# Altium schematic sheet Power.SchDoc
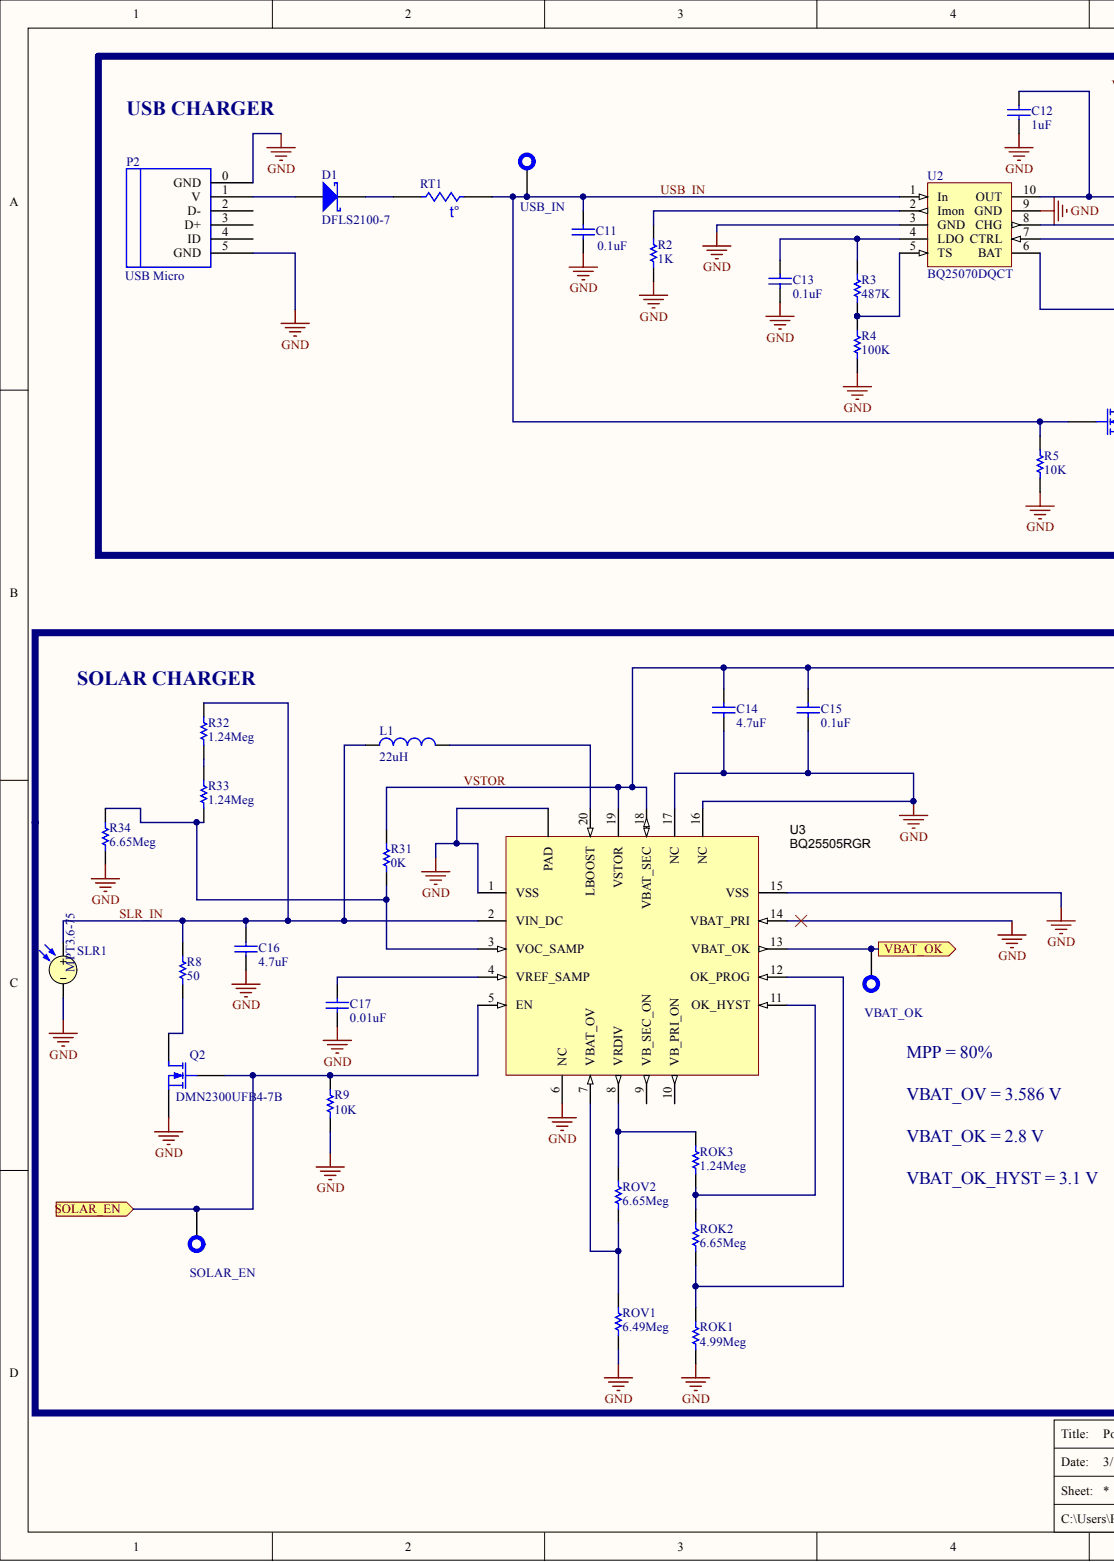
[diagram p0: Power.SchDoc, left]
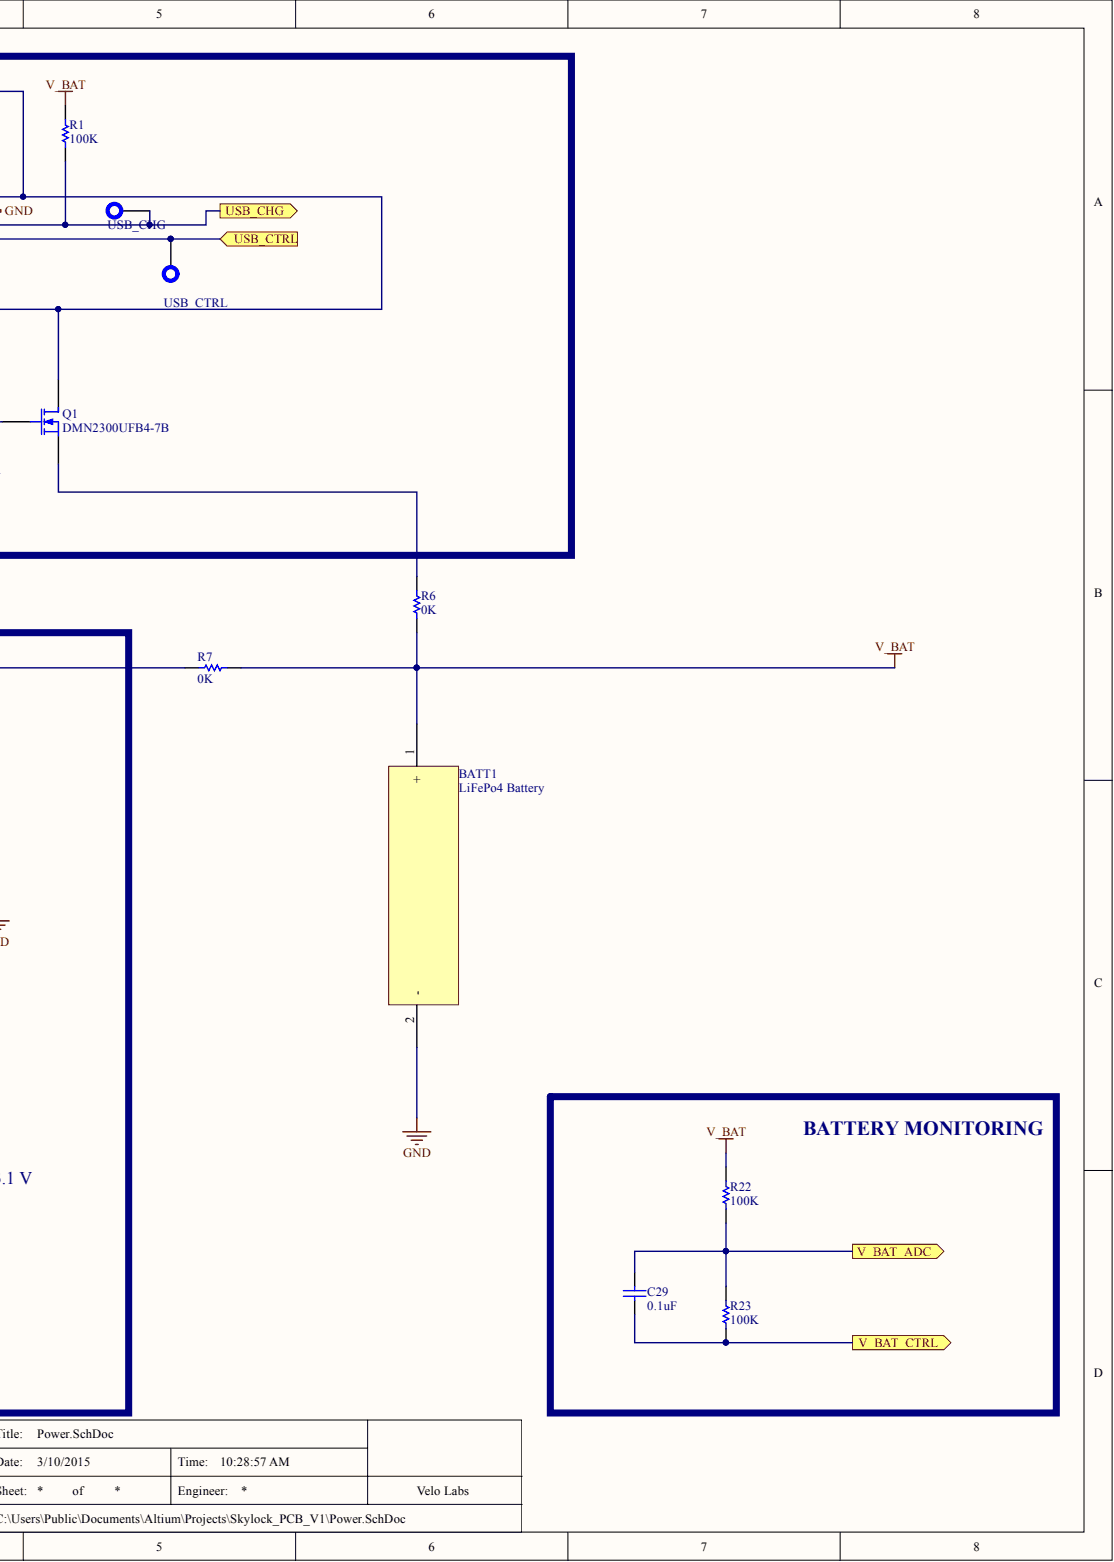
[diagram p1: Power.SchDoc, right]

## Parts
BATT1  LiFePo4 Battery  [Battery holder]
C11  0.1uF  [C0402]
C12  1uF  [C0402]
C13  0.1uF  [C0402]
C14  4.7uF  [C0603]
C15  0.1uF  [C0402]
C16  4.7uF  [C0603]
C17  0.01uF  [C0402]
C29  0.1uF  [C0402]
D1  DFLS2100-7  [POWERDI123]
L1  22uH  [LPS4018]
P2  USB Micro  [TE_micro_USB]
Q1  DMN2300UFB4-7B  [3-DFN]
Q2  DMN2300UFB4-7B  [3-DFN]
R1  100K  [R0402]
R2  1K  [R0402]
R3  487K  [R0402]
R4  100K  [R0402]
R5  10K  [R0402]
R6  0K  [R0603]
R7  0K  [R0603]
R8  50  [R1206]
R9  10K  [R0402]
R22  100K  [R0402]
R23  100K  [R0402]
R31  0K  [R0603]
R32  1.24Meg  [R0402]
R33  1.24Meg  [R0402]
R34  6.65Meg  [R0603]
ROK1  4.99Meg  [R0402]
ROK2  6.65Meg  [R0603]
ROK3  1.24Meg  [R0402]
ROV1  6.49Meg  [R0402]
ROV2  6.65Meg  [R0603]
RT1  PTC RESTTBLE 0.16A 48V CHIP 1206  [1206]  (value not drawn)
SLR1  MPT3.6-75  [Solar_pads]  (no BOM)
SOLAR_EN  Test Point  [TP]  (value not drawn)  (no BOM)
U2  BQ25070DQCT  [QFN50P200X300X80_HS-11N]
U3  BQ25505RGR  [RGR0020A]
USB_CHG  Test Point  [TP]  (value not drawn)  (no BOM)
USB_CTRL  Test Point  [TP]  (value not drawn)  (no BOM)
USB_IN  Test Point  [TP]  (value not drawn)  (no BOM)
VBAT_OK  Test Point  [TP]  (value not drawn)  (no BOM)

## Ports
SOLAR_EN, USB_CHG, USB_CTRL, V_BAT_ADC, V_BAT_CTRL, VBAT_OK

## Nets
GND: BATT1.2 C11.2 C12.2 C13.2 C14.2 C15.2 C16.2 C17.2 P2.0(GND) P2.5(GND) Q2.2 R2.2 R4.2 R5.2 R9.2 R34.1 ROK1.2 ROV1.2 SLR1.2 U2.3(GND) U2.9(GND) U2.11 U3.1(VSS) U3.6(NC) U3.14(VBAT_PRI) U3.15(VSS) U3.16(NC) U3.17(NC) U3.21(PAD)
V_BAT: BATT1.1 R1.1 R6.2 R7.2 R22.1
SLR_IN: C16.1 L1.1 R8.1 R32.2 SLR1.1 U3.2(VIN_DC)
SOLAR_EN: Q2.1 R9.1 SOLAR_EN.1 U3.5(EN) ; port SOLAR_EN
USB_CHG: R1.2 U2.8(CHG) USB_CHG.1 ; port USB_CHG
USB_CTRL: U2.7(CTRL) USB_CTRL.1 ; port USB_CTRL
USB_IN: C11.1 Q1.1 R5.1 RT1.1 U2.1(In) USB_IN.1
V_BAT_ADC: C29.1 R22.2 R23.1 ; port V_BAT_ADC
V_BAT_CTRL: C29.2 R23.2 ; port V_BAT_CTRL
VBAT_OK: U3.13(VBAT_OK) VBAT_OK.1 ; port VBAT_OK
VSTOR: C14.1 C15.1 R7.1 R31.2 U3.18(VBAT_SEC) U3.19(VSTOR)
NetC12_1: C12.1 Q1.3 U2.6(BAT) U2.10(OUT)
NetC13_1: C13.1 R3.1 U2.4(LDO)
NetC17_1: C17.1 U3.4(VREF_SAMP)
NetD1_1: D1.1 RT1.2
NetD1_2: D1.2 P2.1(V)
NetL1_2: L1.2 U3.20(LBOOST)
NetQ1_2: Q1.2 R6.1
NetQ2_3: Q2.3 R8.2
NetR2_1: R2.1 U2.2(Imon)
NetR3_2: R3.2 R4.1 U2.5(TS)
NetR31_1: R31.1 R33.1 R34.2 U3.3(VOC_SAMP)
NetR32_1: R32.1 R33.2
NetROK1_1: ROK1.1 ROK2.2 U3.12(OK_PROG)
NetROK2_1: ROK2.1 ROK3.2 U3.11(OK_HYST)
NetROK3_1: ROK3.1 ROV2.1 U3.8(VRDIV)
NetROV1_1: ROV1.1 ROV2.2 U3.7(VBAT_OV)

Not connected: P2 2(D-) 3(D+) 4(ID); U3 9(VB_SEC_ON) 10(VB_PRI_ON)

## Library data of the parts
BATT1 = LiFePo4 Battery: Battery; Component Kind: Standard; Library Name: VL_Skylock_Nordic.SCHLIB
C11 C13 C15 C29 = Cap Semi: Capacitor; Manufacturer: Murata Electronics North America; Manufacturer Part Number: GRM155R61A104KA01D; Capacitance: 0.1µF; Temperature Coefficient: X5R; Tolerance: ±10%; Voltage - Rated: 10V; Applications: General Purpose; Category: Capacitors; Component Kind: Standard; ComponentLink1Description: http://www.murata.com/~/media/webrenewal/support/library/catalog/products/capacitor/mlcc/c02e.ashx; ComponentLink1URL: http://www.murata.com/~/media/webrenewal/support/library/catalog/products/capacitor/mlcc/c02e.ashx; Features: -; Height - Seated (Max): -; LatestRevisionDate: 29-May-2009; LatestRevisionNote: IPC-7351 Footprint Added.; Lead Spacing: -; Lead Style: -; Mounting Type: Surface Mount, MLCC; Operating Temperature: -55°C ~ 85°C; Package / Case: 0402 (1005 Metric); PackageDescription: Chip Capacitor; PackageReference: C1206; Packaging: Cut Tape (CT); Pricing 1: 1=0.1, 10=0.012, 50=0.0066, 100=0.0055, 250=0.00452, 500=0.00386, 1000=0.003, 5000=0.0025 (USD); Published: 8-Jun-2000; Ratings: -; RoHS: RoHS Compliant; Signal Integrity: Cap Semi; Simulation: CAP; Size / Dimension: 0.039" L x 0.020" W (1.00mm x 0.50mm); Supplier 1: Digi-Key; Supplier Part Number 1: 490-1318-1-ND; Thickness (Max): 0.022" (0.55mm); Value: 0.1uF; Library Name: VL_Skylock_Nordic.SCHLIB; Publisher: Altium Limited
  C11 C15 C29: Stock 1: 7992964
  C13: Stock 1: 7995514
C12 = Cap Semi: Capacitor; Manufacturer: Murata Electronics North America; Manufacturer Part Number: GRM155R60J105KE19D; Capacitance: 1µF; Temperature Coefficient: X5R; Tolerance: ±10%; Voltage - Rated: 6.3V; Applications: General Purpose; Category: Capacitors; Component Kind: Standard; ComponentLink1Description: http://www.murata.com/~/media/webrenewal/support/library/catalog/products/capacitor/mlcc/c02e.ashx; ComponentLink1URL: http://www.murata.com/~/media/webrenewal/support/library/catalog/products/capacitor/mlcc/c02e.ashx; Features: -; Height - Seated (Max): -; LatestRevisionDate: 29-May-2009; LatestRevisionNote: IPC-7351 Footprint Added.; Lead Spacing: -; Lead Style: -; Mounting Type: Surface Mount, MLCC; Operating Temperature: -55°C ~ 85°C; Package / Case: 0402 (1005 Metric); PackageDescription: Chip Capacitor; PackageReference: C1206; Packaging: Cut Tape (CT); Pricing 1: 1=0.1, 10=0.035, 50=0.0192, 100=0.0163, 250=0.01332, 500=0.01138, 1000=0.0087, 5000=0.00725 (USD); Published: 8-Jun-2000; Ratings: -; RoHS: RoHS Compliant; Signal Integrity: Cap Semi; Simulation: CAP; Size / Dimension: 0.039" L x 0.020" W (1.00mm x 0.50mm); Stock 1: 3470799; Supplier 1: Digi-Key; Supplier Part Number 1: 490-1320-1-ND; Thickness (Max): 0.022" (0.55mm); Value: 1uF; Library Name: VL_Skylock_Nordic.SCHLIB; Publisher: Altium Limited
C14 C16 = Cap Semi: Capacitor; Manufacturer: Taiyo Yuden; Manufacturer Part Number: LMK107BJ475KA-T; Capacitance: 4.7µF; Temperature Coefficient: X5R; Tolerance: ±10%; Voltage - Rated: 10V; Applications: General Purpose; Category: Capacitors; Component Kind: Standard; ComponentLink1Description: http://www.yuden.co.jp/or/product/category/capacitor/LMK107BJ475KA-T.pdf; ComponentLink1URL: http://www.yuden.co.jp/or/product/category/capacitor/LMK107BJ475KA-T.pdf; Features: -; Height - Seated (Max): -; LatestRevisionDate: 29-May-2009; LatestRevisionNote: IPC-7351 Footprint Added.; Lead Spacing: -; Lead Style: -; Mounting Type: Surface Mount, MLCC; Operating Temperature: -55°C ~ 85°C; Package / Case: 0603 (1608 Metric); PackageDescription: Chip Capacitor; PackageReference: C1206; Packaging: Cut Tape (CT); Pricing 1: 1=0.23, 10=0.157, 50=0.095, 100=0.0798, 250=0.0646, 500=0.057, 1000=0.04845 (USD); Published: 8-Jun-2000; Ratings: -; RoHS: RoHS Compliant; Signal Integrity: Cap Semi; Simulation: CAP; Size / Dimension: 0.063" L x 0.031" W (1.60mm x 0.80mm); Stock 1: 439312; Supplier 1: Digi-Key; Supplier Part Number 1: 587-1441-1-ND; Thickness (Max): 0.035" (0.90mm); Value: 4.7uF; Library Name: VL_Skylock_Nordic.SCHLIB; Publisher: Altium Limited
C17 = Cap Semi: Capacitor; Manufacturer: Murata Electronics North America; Manufacturer Part Number: GRM155R71E103KA01D; Capacitance: 10000pF; Temperature Coefficient: X7R; Tolerance: ±10%; Voltage - Rated: 25V; Applications: General Purpose; Category: Capacitors; Component Kind: Standard; ComponentLink1Description: http://www.murata.com/~/media/webrenewal/support/library/catalog/products/capacitor/mlcc/c02e.ashx; ComponentLink1URL: http://www.murata.com/~/media/webrenewal/support/library/catalog/products/capacitor/mlcc/c02e.ashx; Features: -; Height - Seated (Max): -; LatestRevisionDate: 29-May-2009; LatestRevisionNote: IPC-7351 Footprint Added.; Lead Spacing: -; Lead Style: -; Mounting Type: Surface Mount, MLCC; Operating Temperature: -55°C ~ 125°C; Package / Case: 0402 (1005 Metric); PackageDescription: Chip Capacitor; PackageReference: C1206; Packaging: Cut Tape (CT); Pricing 1: 1=0.1, 10=0.012, 50=0.0066, 100=0.0057, 250=0.00464, 500=0.00396, 1000=0.00309, 5000=0.00258 (USD); Published: 8-Jun-2000; Ratings: -; RoHS: RoHS Compliant; Signal Integrity: Cap Semi; Simulation: CAP; Size / Dimension: 0.039" L x 0.020" W (1.00mm x 0.50mm); Stock 1: 3508455; Supplier 1: Digi-Key; Supplier Part Number 1: 490-1312-1-ND; Thickness (Max): 0.022" (0.55mm); Value: 0.01uF; Library Name: VL_Skylock_Nordic.SCHLIB; Publisher: Altium Limited
D1 = DFLS2100-7: DIODE SCHOTKY 100V 2A POWERDI123; Manufacturer: Diodes Incorporated; Capacitance @ Vr, F: 36pF @ 5V, 1MHz; Current - Reverse Leakage @ Vr: 1µA @ 100V; Diode Type: Schottky; Speed: Fast Recovery =< 500ns, > 200mA (Io); Voltage - Forward (Vf) (Max) @ If: 860mV @ 2A; Category: Discrete Semiconductor Products; Component Kind: Standard; ComponentLink1Description: http://www.diodes.com/datasheets/ds31475.pdf; ComponentLink1URL: http://www.diodes.com/datasheets/ds31475.pdf; Current - Average Rectified (Io): 2A; Description 1: DIODE SCHOTKY 100V 2A POWERDI123; Manufacturer Part Number: DFLS2100-7; Mounting Type: Surface Mount; Operating Temperature - Junction: -55°C ~ 175°C; Package / Case: 2-SMD, Flat Lead; Packaging: Cut Tape (CT); Pricing 1: 1=0.74, 10=0.65, 25=0.5744, 100=0.5004, 250=0.4356, 500=0.3708, 1000=0.297 (USD); Reverse Recovery Time (trr): -; RoHS: RoHS Compliant; Stock 1: 21950; Supplier 1: Digi-Key; Supplier Device Package: PowerDI™ 123; Supplier Part Number 1: DFLS2100-7DICT-ND; Voltage - DC Reverse (Vr) (Max): 100V; Library Name: VL_Skylock_Nordic.SCHLIB
L1 = Inductor: Fixed Inductors 22 uH 0.9 A 20% SMD Shielded; Manufacturer Part Number: LPS4018-223MRC; Brand: Coilcraft; Case Height: 1.7 mm; Case Length: 4 mm; Code_IEC: 1005; Core Material: Ferrite; Maximum DC Current: 830 mA; Maximum DC Resistance: 360 mOhms; Product Status: New Product; Self Resonant Frequency: 26 MHz; Test Frequency: 100 kHz; Type: Shielded Power Inductor; Case Width: 4 mm; Category: Fixed Inductors; Code_JEITA: 0402; Component Kind: Standard; ComponentLink1Description: http://www.coilcraft.com/lps4018.cfm; ComponentLink1URL: http://www.coilcraft.com/lps4018.cfm; Description 1: Fixed Inductors 22 uH 0.9 A 20% SMD Shielded; Inductance: 22 uH; LatestRevisionDate: 29-May-2009; LatestRevisionNote: IPC-7351 Footprint Added.; Manufacturer: Coilcraft; Minimum Order Quantity: 1000; Operating Temperature Range: - 40 C to + 85 C; PackageDescription: Chip Inductor; PackageReference: 0402-A; Packaging: Reel; Pricing 1: 1000=0.684, 2000=0.637 (AUD); Product: Power Inductors; Published: 8-Jun-2000; Reeling: True; RoHS: RoHS Compliant; RoHS - Mouser: Y; Series: LPS4018; Shielding: Shielded; Signal Integrity: Inductor; Simulation: INDUCTOR; Stock 1: 7000; Supplier 1: Mouser; Supplier Part Number 1: 994-LPS4018-223MRC; Termination Style: SMD/SMT; Tolerance: 20 %; Value: 22uH; Library Name: VL_Skylock_Nordic.SCHLIB; Publisher: Altium Limited
P2 = Micro_USB_splashproof: TE Connectivity SPLASH PROOF MICRO 5P USB AB-TYPE; Manufacturer Part Number: 1551629-2; Brand: TE Connectivity; Category: TE Connectivity; Component Kind: Standard; ComponentLink1Description: http://www.te.com/catalog/pn/en/1551629-2; ComponentLink1URL: http://www.te.com/catalog/pn/en/1551629-2; Manufacturer: TE Connectivity; Minimum Order Quantity: 56000; Packaging: Bulk; Pricing 1: 56000=12.74 (AUD); Reeling: False; RoHS: RoHS Compliant; RoHS - Mouser: Y; Standard Pack Qty: 1000; Stock 1: 0; Supplier 1: Mouser; Supplier Part Number 1: 571-1551629-2; Library Name: VL_Skylock_Nordic.SCHLIB
Q1 Q2 = DMN2300UFB4-7B: MOSF N CH 20V 1.3A DFN1006H4-3; Manufacturer: Diodes Inc; Current - Continuous Drain (Id) @ 25° C: 1.3A (Ta); FET Feature: Logic Level Gate; FET Type: MOSFET N-Channel, Metal Oxide; Gate Charge (Qg) @ Vgs: 1.6nC @ 4.5V; Input Capacitance (Ciss) @ Vds: 64.3pF @ 25V; Power - Max: 470mW; Rds On (Max) @ Id, Vgs: 175 mOhm @ 300mA, 4.5V; Vgs(th) (Max) @ Id: 950mV @ 250µA; Category: Discrete Semiconductor Products; Component Kind: Standard; ComponentLink1Description: http://www.diodes.com/datasheets/DMN2300UFB4.pdf; ComponentLink1URL: http://www.diodes.com/datasheets/DMN2300UFB4.pdf; Description 1: MOSF N CH 20V 1.3A DFN1006H4-3; Drain to Source Voltage (Vdss): 20V; Manufacturer Part Number: DMN2300UFB4-7B; Mounting Type: Surface Mount; Package / Case: 3-XFDFN; Packaging: Digi-Reel®; Pricing 1: 1=0.48, 10=0.348, 25=0.2704, 100=0.2048, 250=0.14476, 500=0.11588, 1000=0.08888, 2500=0.07725, 5000=0.06938 (USD); RoHS: RoHS Compliant; Simulation: NMOS; Stock 1: 61054; Supplier 1: Digi-Key; Supplier Device Package: 3-DFN1006H4 (1.0x0.6); Supplier Part Number 1: DMN2300UFB4-7BDIDKR-ND; Library Name: VL_Skylock_Nordic.SCHLIB
R1 R22 R23 = Res: Resistor; Manufacturer: Panasonic Electronic Components; Manufacturer Part Number: ERJ-2GEJ104X; Power (Watts): 0.1W, 1/10W; Temperature Coefficient: ±200ppm/°C; Tolerance: ±5%; Category: Resistors; Component Kind: Standard; ComponentLink1Description: http://industrial.panasonic.com/www-cgi/jvcr13pz.cgi?E+PZ+3+AOA0001+ERJ2GEJ104X+7+WW; ComponentLink1URL: http://industrial.panasonic.com/www-cgi/jvcr13pz.cgi?E+PZ+3+AOA0001+ERJ2GEJ104X+7+WW; Composition: Thick Film; Features: Automotive AEC-Q200; Height: 0.016" (0.40mm); LatestRevisionDate: 17-Jul-2002; LatestRevisionNote: Re-released for DXP Platform.; Number of Terminations: 2; Operating Temperature: -55°C ~ 155°C; Package / Case: 0402 (1005 Metric); PackageDescription: Axial Device, Thru-Hole; 2 Leads; 0.3 in Pin Spacing; PackageReference: AXIAL-0.3; Packaging: Cut Tape (CT); Pricing 1: 1=0.1, 50=0.0138, 100=0.0105, 250=0.0078, 500=0.00576, 1000=0.00384, 5000=0.0027 (USD); Published: 8-Jun-2000; Resistance (Ohms): 100k; RoHS: RoHS Compliant; Signal Integrity: Res; Simulation: RESISTOR; Size / Dimension: 0.039" L x 0.020" W (1.00mm x 0.50mm); Stock 1: 1549135; Supplier 1: Digi-Key; Supplier Device Package: 0402; Supplier Part Number 1: P100KJCT-ND; Value: 100K; Library Name: VL_Skylock_Nordic.SCHLIB; Publisher: Altium Limited
R2 = Res: Resistor; Manufacturer: Panasonic Electronic Components; Manufacturer Part Number: ERJ-2RKF1001X; Power (Watts): 0.1W, 1/10W; Temperature Coefficient: ±100ppm/°C; Tolerance: ±1%; Category: Resistors; Component Kind: Standard; ComponentLink1Description: http://industrial.panasonic.com/www-cgi/jvcr13pz.cgi?E+PZ+3+AOA0002+ERJ2RKF1001X+7+WW; ComponentLink1URL: http://industrial.panasonic.com/www-cgi/jvcr13pz.cgi?E+PZ+3+AOA0002+ERJ2RKF1001X+7+WW; Composition: Thick Film; Features: Automotive AEC-Q200; Height: 0.016" (0.40mm); LatestRevisionDate: 17-Jul-2002; LatestRevisionNote: Re-released for DXP Platform.; Number of Terminations: 2; Operating Temperature: -55°C ~ 155°C; Package / Case: 0402 (1005 Metric); PackageDescription: Axial Device, Thru-Hole; 2 Leads; 0.3 in Pin Spacing; PackageReference: AXIAL-0.3; Packaging: Cut Tape (CT); Pricing 1: 1=0.1, 50=0.0196, 100=0.0149, 250=0.01104, 500=0.00816, 1000=0.00544, 5000=0.00383 (USD); Published: 8-Jun-2000; Resistance (Ohms): 1k; RoHS: RoHS Compliant; Signal Integrity: Res; Simulation: RESISTOR; Size / Dimension: 0.039" L x 0.020" W (1.00mm x 0.50mm); Stock 1: 2868758; Supplier 1: Digi-Key; Supplier Device Package: 0402; Supplier Part Number 1: P1.00KLCT-ND; Value: 1K; Library Name: VL_Skylock_Nordic.SCHLIB; Publisher: Altium Limited
R3 = Res: Resistor; Manufacturer: Panasonic Electronic Components; Manufacturer Part Number: ERJ-2RKF4873X; Power (Watts): 0.1W, 1/10W; Temperature Coefficient: ±100ppm/°C; Tolerance: ±1%; Category: Resistors; Component Kind: Standard; ComponentLink1Description: http://industrial.panasonic.com/www-cgi/jvcr13pz.cgi?E+PZ+3+AOA0002+ERJ2RKF4873X+7+WW; ComponentLink1URL: http://industrial.panasonic.com/www-cgi/jvcr13pz.cgi?E+PZ+3+AOA0002+ERJ2RKF4873X+7+WW; Composition: Thick Film; Features: Automotive AEC-Q200; Height: 0.016" (0.40mm); LatestRevisionDate: 17-Jul-2002; LatestRevisionNote: Re-released for DXP Platform.; Number of Terminations: 2; Operating Temperature: -55°C ~ 155°C; Package / Case: 0402 (1005 Metric); PackageDescription: Axial Device, Thru-Hole; 2 Leads; 0.3 in Pin Spacing; PackageReference: AXIAL-0.3; Packaging: Cut Tape (CT); Pricing 1: 1=0.1, 50=0.0196, 100=0.0149, 250=0.01104, 500=0.00816, 1000=0.00544, 5000=0.00383 (USD); Published: 8-Jun-2000; Resistance (Ohms): 487k; RoHS: RoHS Compliant; Signal Integrity: Res; Simulation: RESISTOR; Size / Dimension: 0.039" L x 0.020" W (1.00mm x 0.50mm); Stock 1: 78640; Supplier 1: Digi-Key; Supplier Device Package: 0402; Supplier Part Number 1: P487KLCT-ND; Value: 487K; Library Name: VL_Skylock_Nordic.SCHLIB; Publisher: Altium Limited
R4 = Res: Resistor; Manufacturer: Panasonic Electronic Components; Manufacturer Part Number: ERJ-2RKF1003X; Power (Watts): 0.1W, 1/10W; Temperature Coefficient: ±100ppm/°C; Tolerance: ±1%; Category: Resistors; Component Kind: Standard; ComponentLink1Description: http://industrial.panasonic.com/www-cgi/jvcr13pz.cgi?E+PZ+3+AOA0002+ERJ2RKF1003X+7+WW; ComponentLink1URL: http://industrial.panasonic.com/www-cgi/jvcr13pz.cgi?E+PZ+3+AOA0002+ERJ2RKF1003X+7+WW; Composition: Thick Film; Features: Automotive AEC-Q200; Height: 0.016" (0.40mm); LatestRevisionDate: 17-Jul-2002; LatestRevisionNote: Re-released for DXP Platform.; Number of Terminations: 2; Operating Temperature: -55°C ~ 155°C; Package / Case: 0402 (1005 Metric); PackageDescription: Axial Device, Thru-Hole; 2 Leads; 0.3 in Pin Spacing; PackageReference: AXIAL-0.3; Packaging: Cut Tape (CT); Pricing 1: 1=0.1, 50=0.0196, 100=0.0149, 250=0.01104, 500=0.00816, 1000=0.00544, 5000=0.00383 (USD); Published: 8-Jun-2000; Resistance (Ohms): 100k; RoHS: RoHS Compliant; Signal Integrity: Res; Simulation: RESISTOR; Size / Dimension: 0.039" L x 0.020" W (1.00mm x 0.50mm); Stock 1: 4313571; Supplier 1: Digi-Key; Supplier Device Package: 0402; Supplier Part Number 1: P100KLCT-ND; Value: 100K; Library Name: VL_Skylock_Nordic.SCHLIB; Publisher: Altium Limited
R5 R9 = Res: Resistor; Manufacturer: Panasonic Electronic Components; Manufacturer Part Number: ERJ-2GEJ102X; Power (Watts): 0.1W, 1/10W; Resistance (Ohms): 1k; Temperature Coefficient: ±200ppm/°C; Tolerance: ±5%; Category: Resistors; Component Kind: Standard; ComponentLink1Description: http://industrial.panasonic.com/www-cgi/jvcr13pz.cgi?E+PZ+3+AOA0001+ERJ2GEJ102X+7+WW; ComponentLink1URL: http://industrial.panasonic.com/www-cgi/jvcr13pz.cgi?E+PZ+3+AOA0001+ERJ2GEJ102X+7+WW; Composition: Thick Film; Features: Automotive AEC-Q200; Height: 0.016" (0.40mm); LatestRevisionDate: 17-Jul-2002; LatestRevisionNote: Re-released for DXP Platform.; Number of Terminations: 2; Operating Temperature: -55°C ~ 155°C; Package / Case: 0402 (1005 Metric); PackageDescription: Axial Device, Thru-Hole; 2 Leads; 0.3 in Pin Spacing; PackageReference: AXIAL-0.3; Packaging: Cut Tape (CT); Pricing 1: 1=0.1, 50=0.0138, 100=0.0105, 250=0.0078, 500=0.00576, 1000=0.00384, 5000=0.0027 (USD); Published: 8-Jun-2000; RoHS: RoHS Compliant; Signal Integrity: Res; Simulation: RESISTOR; Size / Dimension: 0.039" L x 0.020" W (1.00mm x 0.50mm); Supplier 1: Digi-Key; Supplier Device Package: 0402; Supplier Part Number 1: P1.0KJCT-ND; Value: 10K; Library Name: VL_Skylock_Nordic.SCHLIB; Publisher: Altium Limited
  R5: Stock 1: 1267887
  R9: Stock 1: 1267587
R6 R7 R31 = Res: Resistor; Manufacturer: Panasonic Electronic Components; Manufacturer Part Number: ERJ-3GEY0R00V; Power (Watts): 0.1W, 1/10W; Resistance (Ohms): 0.0; Tolerance: Jumper; Category: Resistors; Component Kind: Standard; ComponentLink1Description: http://industrial.panasonic.com/www-cgi/jvcr13pz.cgi?E+PZ+3+AOA0001+ERJ3GEY0R00V+7+WW; ComponentLink1URL: http://industrial.panasonic.com/www-cgi/jvcr13pz.cgi?E+PZ+3+AOA0001+ERJ3GEY0R00V+7+WW; Composition: Thick Film; Features: Automotive AEC-Q200; Height: 0.022" (0.55mm); LatestRevisionDate: 17-Jul-2002; LatestRevisionNote: Re-released for DXP Platform.; Number of Terminations: 2; Operating Temperature: -55°C ~ 155°C; Package / Case: 0603 (1608 Metric); PackageDescription: Axial Device, Thru-Hole; 2 Leads; 0.3 in Pin Spacing; PackageReference: AXIAL-0.3; Packaging: Cut Tape (CT); Pricing 1: 1=0.1, 50=0.0126, 100=0.0096, 250=0.00716, 500=0.00528, 1000=0.00352, 2500=0.00297 (USD); Published: 8-Jun-2000; RoHS: RoHS Compliant; Signal Integrity: Res; Simulation: RESISTOR; Size / Dimension: 0.063" L x 0.031" W (1.60mm x 0.80mm); Supplier 1: Digi-Key; Supplier Device Package: 0603; Supplier Part Number 1: P0.0GCT-ND; Temperature Coefficient: -; Value: 0K; Library Name: VL_Skylock_Nordic.SCHLIB; Publisher: Altium Limited
  R6 R7: Stock 1: 5836923
  R31: Stock 1: 5282845
R8 = Res: Resistor; Manufacturer: Panasonic Electronic Components; Manufacturer Part Number: ERJ-8ENF51R1V; Power (Watts): 0.25W, 1/4W; Resistance (Ohms): 51.1; Temperature Coefficient: ±100ppm/°C; Tolerance: ±1%; Category: Resistors; Component Kind: Standard; ComponentLink1Description: http://industrial.panasonic.com/www-cgi/jvcr13pz.cgi?E+PZ+3+AOA0002+ERJ8ENF51R1V+7+WW; ComponentLink1URL: http://industrial.panasonic.com/www-cgi/jvcr13pz.cgi?E+PZ+3+AOA0002+ERJ8ENF51R1V+7+WW; Composition: Thick Film; Features: Automotive AEC-Q200; Height: 0.028" (0.70mm); LatestRevisionDate: 17-Jul-2002; LatestRevisionNote: Re-released for DXP Platform.; Number of Terminations: 2; Operating Temperature: -55°C ~ 155°C; Package / Case: 1206 (3216 Metric); PackageDescription: Axial Device, Thru-Hole; 2 Leads; 0.3 in Pin Spacing; PackageReference: AXIAL-0.3; Packaging: Cut Tape (CT); Pricing 1: 1=0.1, 50=0.0368, 100=0.028, 250=0.0208, 500=0.01536, 1000=0.01024 (USD); Published: 8-Jun-2000; RoHS: RoHS Compliant; Signal Integrity: Res; Simulation: RESISTOR; Size / Dimension: 0.126" L x 0.063" W (3.20mm x 1.60mm); Stock 1: 66578; Supplier 1: Digi-Key; Supplier Device Package: 1206; Supplier Part Number 1: P51.1FCT-ND; Value: 50; Library Name: VL_Skylock_Nordic.SCHLIB; Publisher: Altium Limited
R32 R33 ROK3 = Res: Resistor; Manufacturer: Vishay Dale; Manufacturer Part Number: CRCW04021M24FKED; Power (Watts): 0.063W, 1/16W; Resistance (Ohms): 1.24M; Temperature Coefficient: ±100ppm/°C; Tolerance: ±1%; Category: Resistors; Component Kind: Standard; ComponentLink1Description: http://www.vishay.com/docs/20035/dcrcwe3.pdf; ComponentLink1URL: http://www.vishay.com/docs/20035/dcrcwe3.pdf; Composition: Thick Film; Features: Automotive AEC-Q200; Height: 0.016" (0.40mm); LatestRevisionDate: 17-Jul-2002; LatestRevisionNote: Re-released for DXP Platform.; Number of Terminations: 2; Operating Temperature: -55°C ~ 155°C; Package / Case: 0402 (1005 Metric); PackageDescription: Axial Device, Thru-Hole; 2 Leads; 0.3 in Pin Spacing; PackageReference: AXIAL-0.3; Packaging: Cut Tape (CT); Pricing 1: 10=0.083, 50=0.0444, 200=0.0255, 1000=0.0174 (USD); Published: 8-Jun-2000; RoHS: RoHS Compliant; Signal Integrity: Res; Simulation: RESISTOR; Size / Dimension: 0.039" L x 0.020" W (1.00mm x 0.50mm); Supplier 1: Digi-Key; Supplier Device Package: 0402 (1005 Metric); Supplier Part Number 1: 541-1.24MLCT-ND; Value: 1.24Meg; Library Name: VL_Skylock_Nordic.SCHLIB; Publisher: Altium Limited
  R32 R33: Stock 1: 25169
  ROK3: Stock 1: 25129
R34 ROK2 ROV2 = Res: Resistor; Manufacturer: Vishay Dale; Manufacturer Part Number: CRCW06036M65FKEA; Power (Watts): 0.1W, 1/10W; Resistance (Ohms): 6.65M; Temperature Coefficient: ±100ppm/°C; Tolerance: ±1%; Category: Resistors; Component Kind: Standard; ComponentLink1Description: http://www.vishay.com/docs/20035/dcrcwe3.pdf; ComponentLink1URL: http://www.vishay.com/docs/20035/dcrcwe3.pdf; Composition: Thick Film; Features: Automotive AEC-Q200; Height: 0.020" (0.50mm); LatestRevisionDate: 17-Jul-2002; LatestRevisionNote: Re-released for DXP Platform.; Number of Terminations: 2; Operating Temperature: -55°C ~ 155°C; Package / Case: 0603 (1608 Metric); PackageDescription: Axial Device, Thru-Hole; 2 Leads; 0.3 in Pin Spacing; PackageReference: AXIAL-0.3; Packaging: Cut Tape (CT); Pricing 1: 10=0.081, 50=0.0436, 200=0.0251, 1000=0.01711 (USD); Published: 8-Jun-2000; RoHS: RoHS Compliant; Signal Integrity: Res; Simulation: RESISTOR; Size / Dimension: 0.061" L x 0.033" W (1.55mm x 0.85mm); Stock 1: 19192; Supplier 1: Digi-Key; Supplier Device Package: 0603 (1608 Metric); Supplier Part Number 1: 541-6.65MHCT-ND; Value: 6.65Meg; Library Name: VL_Skylock_Nordic.SCHLIB; Publisher: Altium Limited
ROK1 = Res: Resistor; Manufacturer: Vishay Dale; Manufacturer Part Number: CRCW04024M99FKED; Power (Watts): 0.063W, 1/16W; Resistance (Ohms): 4.99M; Temperature Coefficient: ±100ppm/°C; Tolerance: ±1%; Category: Resistors; Component Kind: Standard; ComponentLink1Description: http://www.vishay.com/docs/20035/dcrcwe3.pdf; ComponentLink1URL: http://www.vishay.com/docs/20035/dcrcwe3.pdf; Composition: Thick Film; Features: Automotive AEC-Q200; Height: 0.016" (0.40mm); LatestRevisionDate: 17-Jul-2002; LatestRevisionNote: Re-released for DXP Platform.; Number of Terminations: 2; Operating Temperature: -55°C ~ 155°C; Package / Case: 0402 (1005 Metric); PackageDescription: Axial Device, Thru-Hole; 2 Leads; 0.3 in Pin Spacing; PackageReference: AXIAL-0.3; Packaging: Cut Tape (CT); Pricing 1: 10=0.083, 50=0.0444, 200=0.0255, 1000=0.0174 (USD); Published: 8-Jun-2000; RoHS: RoHS Compliant; Signal Integrity: Res; Simulation: RESISTOR; Size / Dimension: 0.039" L x 0.020" W (1.00mm x 0.50mm); Stock 1: 393089; Supplier 1: Digi-Key; Supplier Device Package: 0402 (1005 Metric); Supplier Part Number 1: 541-4.99MLCT-ND; Value: 4.99Meg; Library Name: VL_Skylock_Nordic.SCHLIB; Publisher: Altium Limited
ROV1 = Res: Resistor; Manufacturer: Stackpole Electronics Inc; Manufacturer Part Number: RMCF0402FT6M49; Power (Watts): 0.063W, 1/16W; Resistance (Ohms): 6.49M; Temperature Coefficient: ±100ppm/°C; Tolerance: ±1%; Category: Resistors; Component Kind: Standard; ComponentLink1Description: http://www.seielect.com/Catalog/SEI-RMCF_RMCP.pdf; ComponentLink1URL: http://www.seielect.com/Catalog/SEI-RMCF_RMCP.pdf; Composition: Thick Film; Features: Automotive AEC-Q200; Height: 0.016" (0.40mm); LatestRevisionDate: 17-Jul-2002; LatestRevisionNote: Re-released for DXP Platform.; Number of Terminations: 2; Operating Temperature: -55°C ~ 155°C; Package / Case: 0402 (1005 Metric); PackageDescription: Axial Device, Thru-Hole; 2 Leads; 0.3 in Pin Spacing; PackageReference: AXIAL-0.3; Packaging: Cut Tape (CT); Pricing 1: 1=0.1, 10=0.025, 50=0.0138, 100=0.0105, 250=0.0078, 500=0.00576, 1000=0.00384, 2500=0.00324, 5000=0.0027 (USD); Published: 8-Jun-2000; RoHS: RoHS Compliant; Signal Integrity: Res; Simulation: RESISTOR; Size / Dimension: 0.039" L x 0.020" W (1.00mm x 0.50mm); Stock 1: 5415; Supplier 1: Digi-Key; Supplier Device Package: 0402; Supplier Part Number 1: RMCF0402FT6M49CT-ND; Value: 6.49Meg; Library Name: VL_Skylock_Nordic.SCHLIB; Publisher: Altium Limited
RT1 = Res Thermal: Thermistor; Manufacturer: Bel Fuse Inc; Manufacturer Part Number: 0ZCJ0016FF2E; Current - Hold (Ih) (Max): 160mA; Current - Max: 10A; Current - Trip (It): 450mA; R Min/Max: 1.100 ~ 5.000 Ohm; Time to Trip: 0.3s; Category: Circuit Protection; Component Kind: Standard; ComponentLink1Description: http://www.belfuse.com/pdfs/0ZCJ.pdf; ComponentLink1URL: http://www.belfuse.com/pdfs/0ZCJ.pdf; Description 1: PTC RESTTBLE 0.16A 48V CHIP 1206; Package / Case: 1206 (3216 Metric), Concave; Packaging: Cut Tape (CT); Pricing 1: 1=0.12, 10=0.107, 25=0.0992, 100=0.0891, 250=0.0794, 500=0.06616, 1000=0.06269 (USD); RoHS: RoHS Compliant; Stock 1: 9154; Supplier 1: Digi-Key; Supplier Part Number 1: 507-1796-1-ND; Voltage - Max: 48V; Library Name: VL_Skylock_Nordic.SCHLIB
SLR1 = Solar Cell: Photovoltaic Cell; Library Name: VL_Skylock_Nordic.SCHLIB
U2 = BQ25070DQCT: IC LINEAR BATT CHRGR SGL 10WSON; Manufacturer: Texas Instruments; Battery Chemistry: LiFePO4; Function: Charge Management; Voltage - Supply: 3.75 V ~ 28 V; Category: Integrated Circuits (ICs); Component Kind: Standard; ComponentLink1Description: http://www.ti.com/lit/ds/symlink/bq25070.pdf; ComponentLink1URL: http://www.ti.com/lit/ds/symlink/bq25070.pdf; Description 1: IC LINEAR BATT CHRGR SGL 10WSON; Manufacturer Part Number: BQ25070DQCT; Mounting Type: Surface Mount; Operating Temperature: -40°C ~ 85°C; Package / Case: 10-WFDFN Exposed Pad; Packaging: Cut Tape (CT); Pricing 1: 1=2.58, 10=2.333, 25=2.09, 100=1.8792 (USD); RoHS: RoHS Compliant; Stock 1: 2418; Supplier 1: Digi-Key; Supplier Device Package: 10-WSON (3x2); Supplier Part Number 1: 296-29180-1-ND; Library Name: VL_Skylock_Nordic.SCHLIB
U3 = BQ25505RGR: Ultra Low Power Boost Converter with Battery Management for Energy Harvester Applications, RGR0020A; Alternate Manufacturer: None; Manufacturer: Texas Instruments; CompType: IC; SymbolCreator: a0412235; U/M: EA; Component Kind: Standard; ComponentLink1Description: Datasheet Link; ComponentLink1URL: https://sps06.itg.ti.com/sites/KMS/crossdivteams/altium/docs/ComponentDatasheets/bq25505_DS_SLUSBJ3_20130607.pdf; LatestRevisionDate: 2013-09-30; LatestRevisionNote: Added Parts; MountType: SMT; PackageReference: RGR0020A; PartNumber: BQ25505RGR; RoHS: Y; Supplier 1: Digi-Key; Supplier Part Number 1: 296-37078-1-ND; SymbolVersion: 2013-01-07; Library Name: VL_Skylock_Nordic.SCHLIB; Publisher: Texas Instruments
SOLAR_EN USB_CHG USB_CTRL USB_IN VBAT_OK = Test Point: Description: Test Point; Library Name: VL_Skylock_Nordic.SCHLIB
